# Altium schematic sheet RAM.SchDoc(RAM)
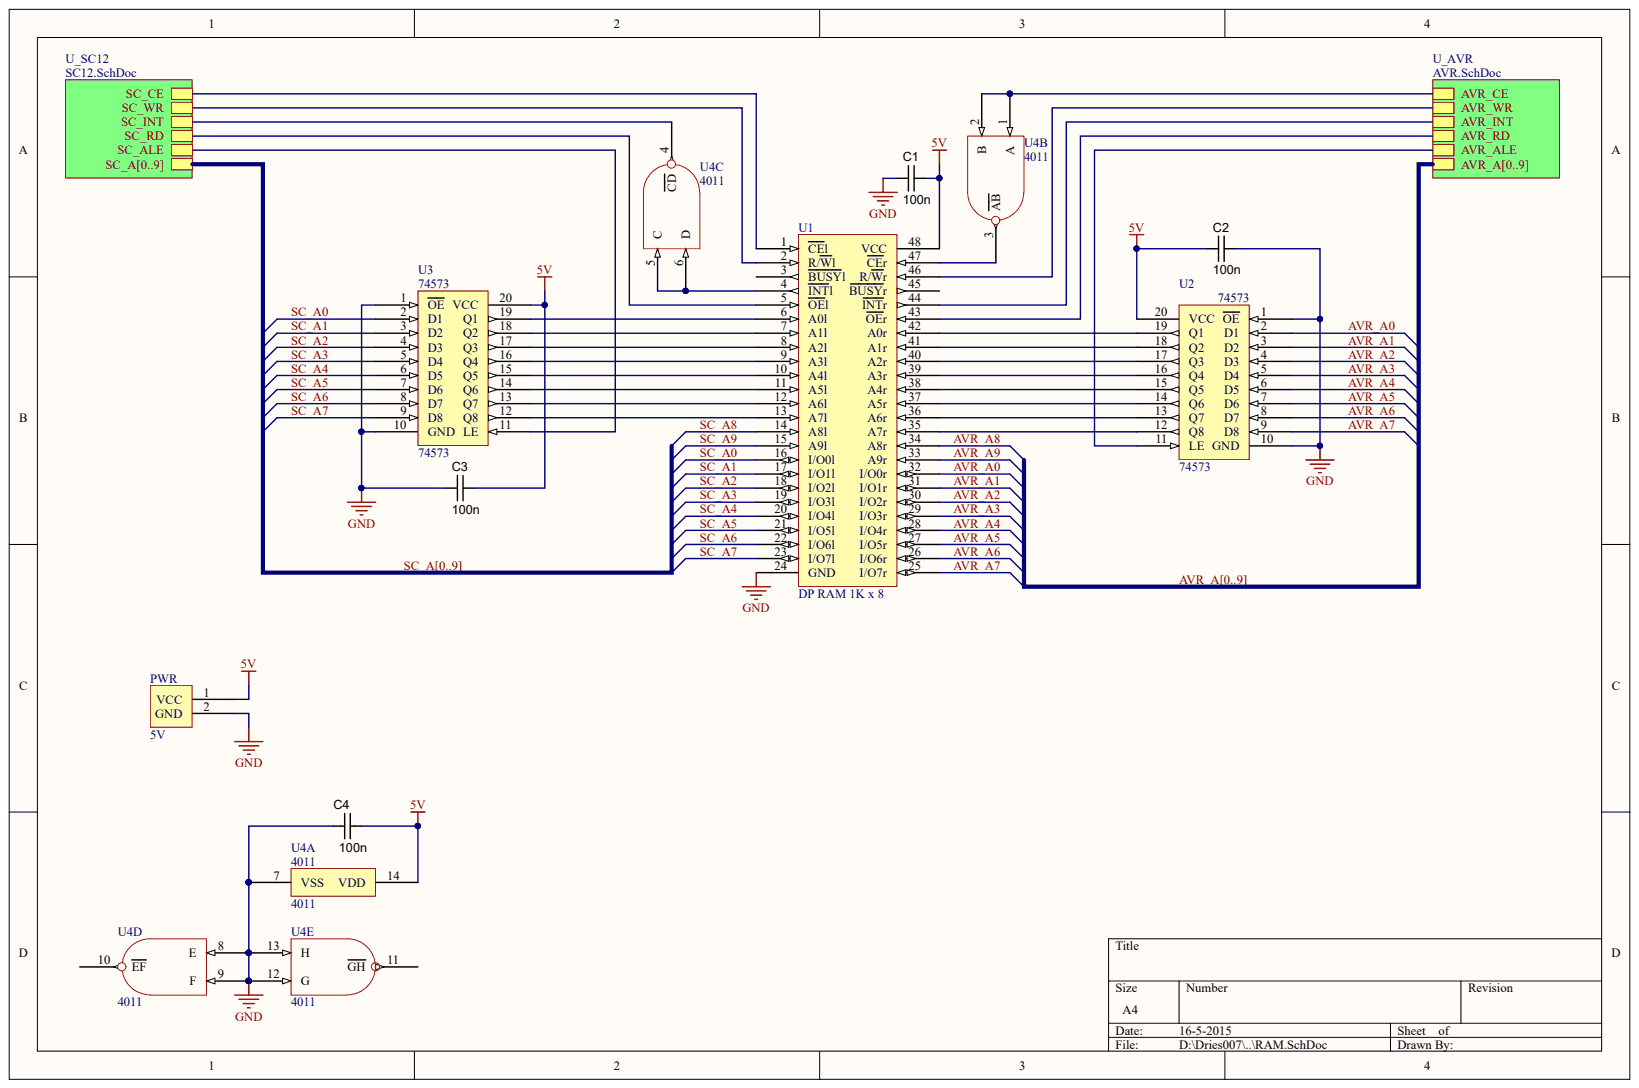
[diagram p0: RAM.SchDoc]

## Parts
C1  value 100n  [CAPC3216X180N]  (hidden comment: CAPC_100n_1206_NP0_50V_5%)
C2  value 100n  [CAPC3216X180N]  (hidden comment: CAPC_100n_1206_NP0_50V_5%)
C3  value 100n  [CAPC3216X180N]  (hidden comment: CAPC_100n_1206_NP0_50V_5%)
C4  value 100n  [CAPC3216X180N]  (hidden comment: CAPC_100n_1206_NP0_50V_5%)
PWR  5V  [H1x2]
U1  DP RAM 1K x 8  [DIL46W]
U2  74573  [DIL20]
U3  74573  [DIL20]
U4  4011  [DIL14]  (parts A,B,C,D,E)

## Nets
5V: C1.1 C2.2 C3.1 C4.1 PWR.1 U1.48(VCC) U2.20(VCC) U3.20(VCC) U4.14(VDD)
GND: C1.2 C2.1 C3.2 C4.2 PWR.2 U1.24(GND) U2.1(OE) U2.10(GND) U3.1(OE) U3.10(GND) U4.7(VSS) U4.8(E) U4.9(F) U4.12(G) U4.13(H)
AVR_A0: U1.32(I/O0r) U2.2(D1)  [also labelled AVR_A]
AVR_A1: U1.31(I/O1r) U2.3(D2)  [also labelled AVR_A]
AVR_A2: U1.30(I/O2r) U2.4(D3)  [also labelled AVR_A]
AVR_A3: U1.29(I/O3r) U2.5(D4)  [also labelled AVR_A]
AVR_A4: U1.28(I/O4r) U2.6(D5)  [also labelled AVR_A]
AVR_A5: U1.27(I/O5r) U2.7(D6)  [also labelled AVR_A]
AVR_A6: U1.26(I/O6r) U2.8(D7)  [also labelled AVR_A]
AVR_A7: U1.25(I/O7r) U2.9(D8)  [also labelled AVR_A]
AVR_A8: U1.34(A8r)  [also labelled AVR_A]
AVR_A9: U1.33(A9r)  [also labelled AVR_A]
SC_A0: U1.16(I/O0l) U3.2(D1)  [also labelled SC_A]
SC_A1: U1.17(I/O1l) U3.3(D2)  [also labelled SC_A]
SC_A2: U1.18(I/O2l) U3.4(D3)  [also labelled SC_A]
SC_A3: U1.19(I/O3l) U3.5(D4)  [also labelled SC_A]
SC_A4: U1.20(I/O4l) U3.6(D5)  [also labelled SC_A]
SC_A5: U1.21(I/O5l) U3.7(D6)  [also labelled SC_A]
SC_A6: U1.22(I/O6l) U3.8(D7)  [also labelled SC_A]
SC_A7: U1.23(I/O7l) U3.9(D8)  [also labelled SC_A]
SC_A8: U1.14(A8l)  [also labelled SC_A]
SC_A9: U1.15(A9l)  [also labelled SC_A]
NetU1_1: U1.1(CEl)
NetU1_2: U1.2(R/Wl)
NetU1_3: U1.3(BUSYl)
NetU1_4: U1.4(INTl) U4.5(C) U4.6(D)
NetU1_5: U1.5(OEl)
NetU1_6: U1.6(A0l) U3.19(Q1)
NetU1_7: U1.7(A1l) U3.18(Q2)
NetU1_8: U1.8(A2l) U3.17(Q3)
NetU1_9: U1.9(A3l) U3.16(Q4)
NetU1_10: U1.10(A4l) U3.15(Q5)
NetU1_11: U1.11(A5l) U3.14(Q6)
NetU1_12: U1.12(A6l) U3.13(Q7)
NetU1_13: U1.13(A7l) U3.12(Q8)
NetU1_35: U1.35(A7r) U2.12(Q8)
NetU1_36: U1.36(A6r) U2.13(Q7)
NetU1_37: U1.37(A5r) U2.14(Q6)
NetU1_38: U1.38(A4r) U2.15(Q5)
NetU1_39: U1.39(A3r) U2.16(Q4)
NetU1_40: U1.40(A2r) U2.17(Q3)
NetU1_41: U1.41(A1r) U2.18(Q2)
NetU1_42: U1.42(A0r) U2.19(Q1)
NetU1_43: U1.43(OEr)
NetU1_44: U1.44(INTr)
NetU1_45: U1.45(BUSYr)
NetU1_46: U1.46(R/Wr)
NetU1_47: U1.47(CEr) U4.3(AB)
NetU2_11: U2.11(LE)
NetU3_11: U3.11(LE)
NetU4_1: U4.1(A) U4.2(B)
NetU4_4: U4.4(CD)
NetU4_10: U4.10(EF)
NetU4_11: U4.11(GH)

## Library data of the parts
C1-C4 = CAPC_100n_1206_NP0_50V_5%: Capacitor SMD Cer 100nF 1206 NP0 50V 5%; Manufacturer 1: TDK Corporation; Manufacturer Part Number 1: C3216C0G1H104J160AA; Ratings: 50V; NP0; SCDType: undef; Component Kind: Standard; ComponentLink1Description: http://product.tdk.com/capacitor/mlcc/en/documents/mlcc_commercial_general_en.pdf; ComponentLink1URL: http://product.tdk.com/capacitor/mlcc/en/documents/mlcc_commercial_general_en.pdf; Library Name: PBL - Capacitors.SCHLIB; Operating Temperature: -55°C ~ 125°C; Package / Case: 1206 (3216 Metric); Size / Dimension: 0.126" L x 0.063" W (3.20mm x 1.60mm); Supplier 1: Digi-Key; Supplier Part Number 1: 445-7694-1-ND; Thickness (Max): 0.075" (1.90mm); Tolerance: ±5%
PWR = Power2x1: Component Kind: Standard; Library Name: Connectors.SchLib
U1 = DP_RAM_1Kx8: SRAM - Dual Port, Asynchronous, 1K x 8; Component Kind: Standard; Library Name: RAM.SchLib
U2 U3 = 74573: Component Kind: Standard; Description: 74573; Library Name: 7400.SchLib
U4 = 4011: Component Kind: Standard; Description: 4011; Library Name: 4000.SchLib
